# Altium schematic sheet p09_Gig-ENET1.SchDoc
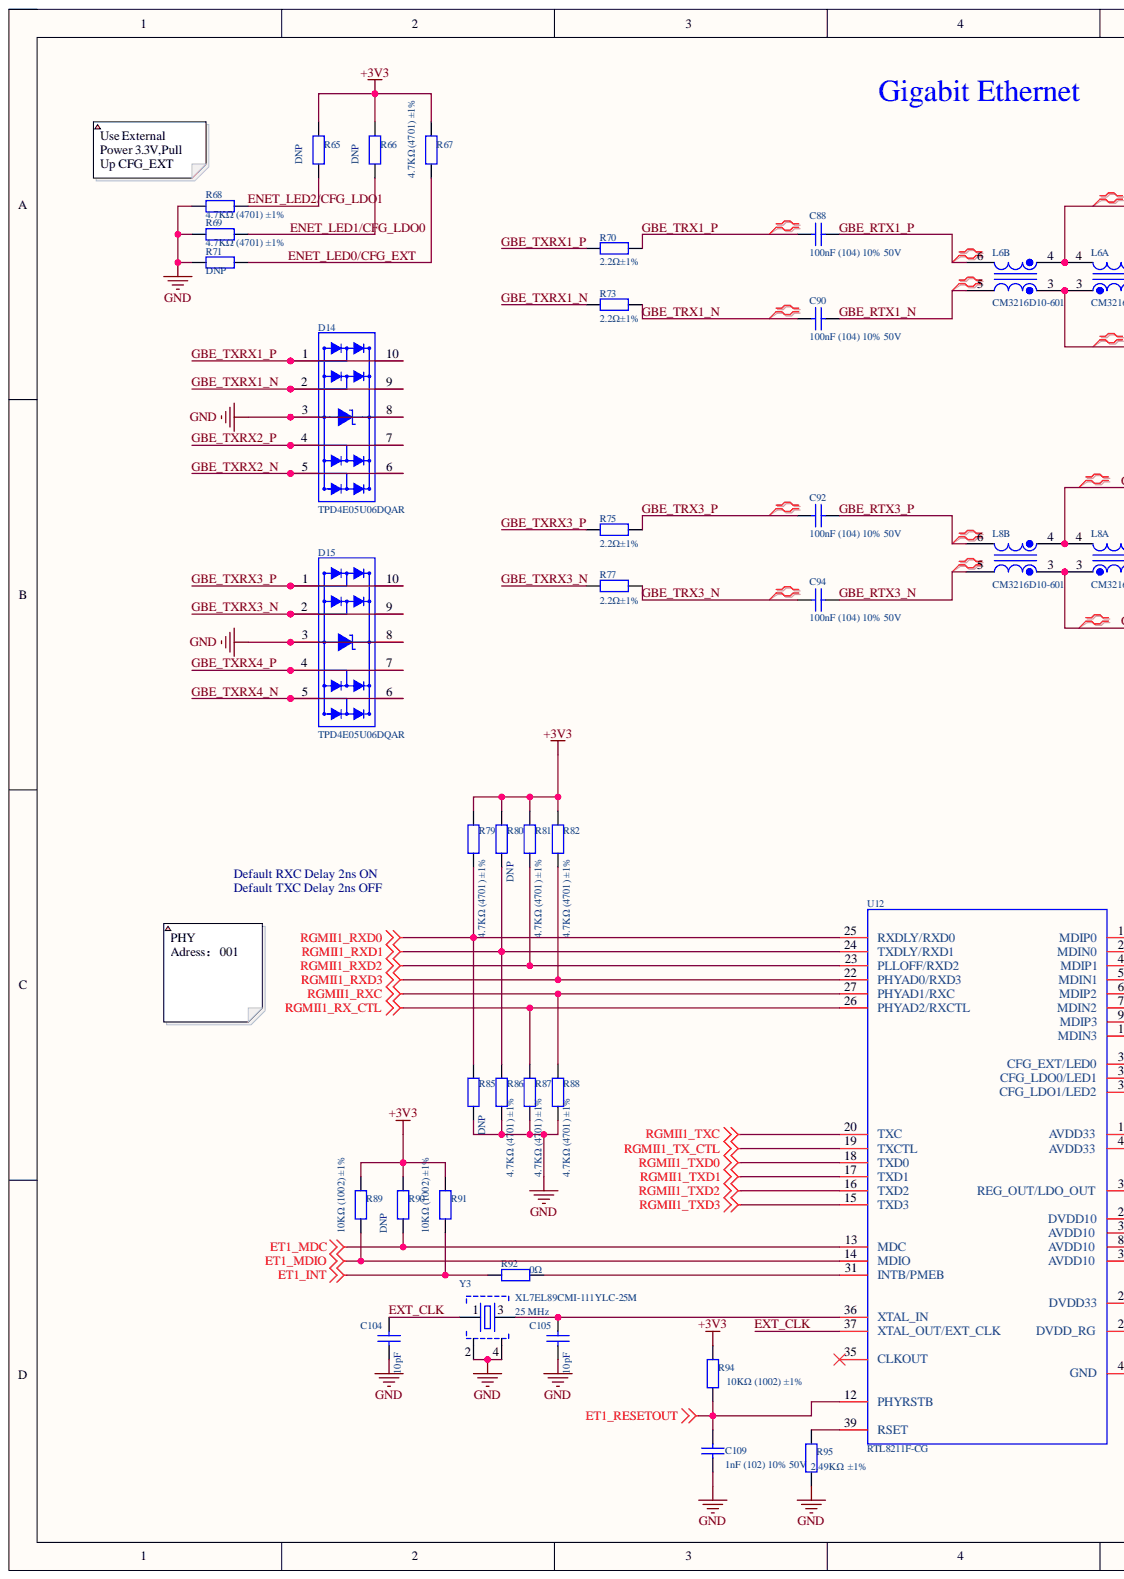
[diagram p0: p09_Gig-ENET1.SchDoc, left]
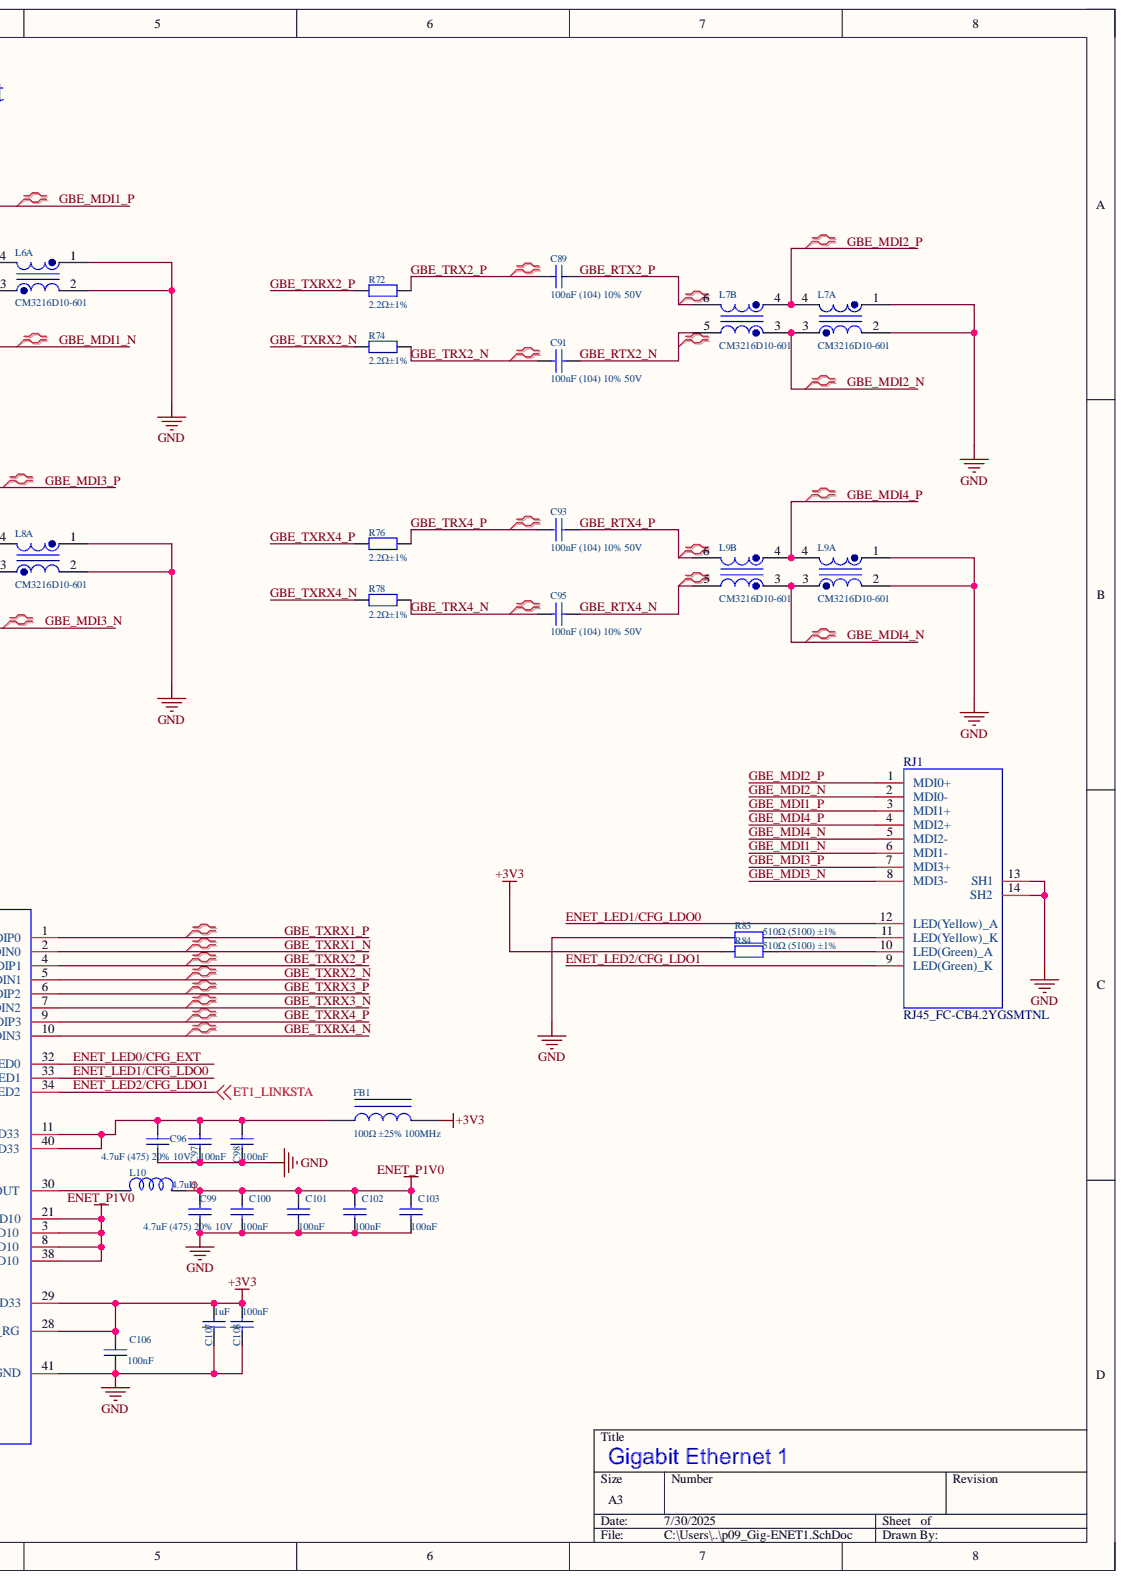
[diagram p1: p09_Gig-ENET1.SchDoc, right]

## Parts
C88  100nF (104) 10% 50V  [C0402]
C89  100nF (104) 10% 50V  [C0402]
C90  100nF (104) 10% 50V  [C0402]
C91  100nF (104) 10% 50V  [C0402]
C92  100nF (104) 10% 50V  [C0402]
C93  100nF (104) 10% 50V  [C0402]
C94  100nF (104) 10% 50V  [C0402]
C95  100nF (104) 10% 50V  [C0402]
C96  4.7uF (475) 20% 10V  [C0402]
C97  Capacitance: 100nF  [C0402]  (hidden comment: 100 nf (0.1uF) 16V)
C98  Capacitance: 100nF  [C0402]  (hidden comment: 100 nf (0.1uF) 16V)
C99  4.7uF (475) 20% 10V  [C0402]
C100  Capacitance: 100nF  [C0402]  (hidden comment: 100 nf (0.1uF) 16V)
C101  Capacitance: 100nF  [C0402]  (hidden comment: 100 nf (0.1uF) 16V)
C102  Capacitance: 100nF  [C0402]  (hidden comment: 100 nf (0.1uF) 16V)
C103  Capacitance: 100nF  [C0402]  (hidden comment: 100 nf (0.1uF) 16V)
C104  Capacitance: 10pF  [C0402]  (hidden comment: 10pF 50V)
C105  Capacitance: 10pF  [C0402]  (hidden comment: 10pF 50V)
C106  Capacitance: 100nF  [C0402]  (hidden comment: 100 nf (0.1uF) 16V)
C107  Capacitance: 1uF  [C0402]  (hidden comment: 1uF 16V)
C108  Capacitance: 100nF  [C0402]  (hidden comment: 100 nf (0.1uF) 16V)
C109  1nF (102) 10% 50V  [C0402]
D14  TPD4E05U06DQAR  [USON-10_L2.5-W1.0-P0.50-BL]
D15  TPD4E05U06DQAR  [USON-10_L2.5-W1.0-P0.50-BL]
FB1  100Ω ±25% 100MHz  [0805_L]
L6  CM3216D10-601  [FILTER-SMD_6P-L3.4-W1.6_SFI1206-601R201]  (parts A,B)
L7  CM3216D10-601  [FILTER-SMD_6P-L3.4-W1.6_SFI1206-601R201]  (parts A,B)
L8  CM3216D10-601  [FILTER-SMD_6P-L3.4-W1.6_SFI1206-601R201]  (parts A,B)
L9  CM3216D10-601  [FILTER-SMD_6P-L3.4-W1.6_SFI1206-601R201]  (parts A,B)
L10  Inductance: 4.7uH  [FB_0805]  (hidden comment: IND-100023-01)
R65  DNP  [R0402]
R66  DNP  [R0402]
R67  4.7KΩ (4701) ±1%  [R0402]
R68  4.7KΩ (4701) ±1%  [R0402]
R69  4.7KΩ (4701) ±1%  [R0402]
R70  2.2Ω±1%  [R0201]
R71  DNP  [R0402]
R72  2.2Ω±1%  [R0201]
R73  2.2Ω±1%  [R0201]
R74  2.2Ω±1%  [R0201]
R75  2.2Ω±1%  [R0201]
R76  2.2Ω±1%  [R0201]
R77  2.2Ω±1%  [R0201]
R78  2.2Ω±1%  [R0201]
R79  4.7KΩ (4701) ±1%  [R0402]
R80  DNP  [R0402]
R81  4.7KΩ (4701) ±1%  [R0402]
R82  4.7KΩ (4701) ±1%  [R0402]
R83  510Ω (5100) ±1%  [R0402]
R84  510Ω (5100) ±1%  [R0402]
R85  DNP  [R0402]
R86  4.7KΩ (4701) ±1%  [R0402]
R87  4.7KΩ (4701) ±1%  [R0402]
R88  4.7KΩ (4701) ±1%  [R0402]
R89  10KΩ (1002) ±1%  [R0402]
R90  DNP  [R0402]
R91  10KΩ (1002) ±1%  [R0402]
R92  0Ω  [R0402]
R94  10KΩ (1002) ±1%  [R0402]
R95  2.49KΩ  ±1%  [R0402]
RJ1  RJ45_FC-CB4.2YGSMTNL  [RJ45-TH_FC-CB4.2YGSMTNL]
U12  RTL8211F-CG  [WQFN-40_L5.0-W5.0-P0.4-BL-EP]
Y3  XL7EL89CMI-111YLC-25M  [CYR-2016]

## Nets
+3V3: C106.1 C107.1 C108.1 FB1.2 R65.2 R66.2 R67.2 R79.2 R80.2 R81.2 R82.2 R84.1 R89.2 R90.2 R91.2 R94.2 U12.28(DVDD_RG) U12.29(DVDD33)
ENET_P1V0: C99.2 C100.2 C101.2 C102.2 C103.2 L10.2 U12.3(AVDD10) U12.8(AVDD10) U12.21(DVDD10) U12.38(AVDD10)
ET1_INT: R91.1 R92.1
ET1_MDC: R90.1 U12.13(MDC)
ET1_MDIO: R89.1 U12.14(MDIO)
ET1_RESETOUT: C109.2 R94.1 U12.12(PHYRSTB)
GND: C96.2 C97.1 C98.2 C99.1 C100.1 C101.1 C102.1 C103.1 C104.1 C105.1 C106.2 C107.2 C108.2 C109.1 D14.3 D14.8 D15.3 D15.8 L6.1 L6.2 L7.1 L7.2 L8.1 L8.2 L9.1 L9.2 R68.1 R69.1 R71.1 R83.1 R85.1 R86.1 R87.1 R88.1 R95.1 RJ1.13(SH1) RJ1.14(SH2) U12.41(GND) Y3.2 Y3.4
RGMII1_RX_CTL: R87.2 U12.26(PHYAD2/RXCTL)
RGMII1_RXC: R88.2 U12.27(PHYAD1/RXC)
RGMII1_RXD0: R79.1 R85.2 U12.25(RXDLY/RXD0)
RGMII1_RXD1: R80.1 R86.2 U12.24(TXDLY/RXD1)
RGMII1_RXD2: R81.1 U12.23(PLLOFF/RXD2)
RGMII1_RXD3: R82.1 U12.22(PHYAD0/RXD3)
RGMII1_TX_CTL: U12.19(TXCTL)
RGMII1_TXC: U12.20(TXC)
RGMII1_TXD0: U12.18(TXD0)
RGMII1_TXD1: U12.17(TXD1)
RGMII1_TXD2: U12.16(TXD2)
RGMII1_TXD3: U12.15(TXD3)
ENET_LED0/CFG_EXT: R67.1 R71.2 U12.32(CFG_EXT/LED0)
ENET_LED1/CFG_LDO0: R66.1 R69.2 RJ1.12(LED(Yellow)_A) U12.33(CFG_LDO0/LED1)
ENET_LED2/CFG_LDO1: R65.1 R68.2 RJ1.9(LED(Green)_K) U12.34(CFG_LDO1/LED2)
EXT_CLK: C104.2 U12.37(XTAL_OUT/EXT_CLK) Y3.1
GBE_MDI1_N: L6.3 RJ1.6(MDI1-)
GBE_MDI1_P: L6.4 RJ1.3(MDI1+)
GBE_MDI2_N: L7.3 RJ1.2(MDI0-)
GBE_MDI2_P: L7.4 RJ1.1(MDI0+)
GBE_MDI3_N: L8.3 RJ1.8(MDI3-)
GBE_MDI3_P: L8.4 RJ1.7(MDI3+)
GBE_MDI4_N: L9.3 RJ1.5(MDI2-)
GBE_MDI4_P: L9.4 RJ1.4(MDI2+)
GBE_RTX1_N: C90.2 L6.5
GBE_RTX1_P: C88.2 L6.6
GBE_RTX2_N: C91.2 L7.5
GBE_RTX2_P: C89.2 L7.6
GBE_RTX3_N: C94.2 L8.5
GBE_RTX3_P: C92.2 L8.6
GBE_RTX4_N: C95.2 L9.5
GBE_RTX4_P: C93.2 L9.6
GBE_TRX1_N: C90.1 R73.2
GBE_TRX1_P: C88.1 R70.2
GBE_TRX2_N: C91.1 R74.2
GBE_TRX2_P: C89.1 R72.2
GBE_TRX3_N: C94.1 R77.2
GBE_TRX3_P: C92.1 R75.2
GBE_TRX4_N: C95.1 R78.2
GBE_TRX4_P: C93.1 R76.2
GBE_TXRX1_N: D14.2 D14.9 R73.1 U12.2(MDIN0)
GBE_TXRX1_P: D14.1 D14.10 R70.1 U12.1(MDIP0)
GBE_TXRX2_N: D14.5 D14.6 R74.1 U12.5(MDIN1)
GBE_TXRX2_P: D14.4 D14.7 R72.1 U12.4(MDIP1)
GBE_TXRX3_N: D15.2 D15.9 R77.1 U12.7(MDIN2)
GBE_TXRX3_P: D15.1 D15.10 R75.1 U12.6(MDIP2)
GBE_TXRX4_N: D15.5 D15.6 R78.1 U12.10(MDIN3)
GBE_TXRX4_P: D15.4 D15.7 R76.1 U12.9(MDIP3)
NetC96_1: C96.1 C97.2 C98.1 FB1.1 U12.11(AVDD33) U12.40(AVDD33)
NetC105_2: C105.2 U12.36(XTAL_IN) Y3.3
NetL10_1: L10.1 U12.30(REG_OUT/LDO_OUT)
NetR83_2: R83.2 RJ1.11(LED(Yellow)_K)
NetR84_2: R84.2 RJ1.10(LED(Green)_A)
NetR92_2: R92.2 U12.31(INTB/PMEB)
NetR95_2: R95.2 U12.39(RSET)

Not connected: U12 35(CLKOUT)

## Library data of the parts
C88-C95 = 0402 100nF (104) 10% 50V: 贴片电容; Component Kind: Standard; ComponentLink1Description: 供应商链接; ComponentLink1URL: https://item.szlcsc.com/111473.html; Library Name: Cap.SchLib; Package: 0402; Supplier: LC; SuppliersPartNumber: C1525
C96 C99 = 0402 4.7uF (475) 20% 10V: 贴片电容; Component Kind: Standard; ComponentLink1Description: 供应商链接; ComponentLink1URL: http://www.szlcsc.com/product/details_24469.html; Library Name: Cap.SchLib; Package: 0402; Supplier: LC; SuppliersPartNumber: C23733
C97 C98 C100-C103 C106 C108 = CAP-000030-01: Ceramic Chip Capacitor 0402 100 nf (0.1uF) 16V; Legacy Part Number: 151-10004022; Manufacturer: Yageo; Manufacturer Part Number 1: CC0402KRX7R8BB104; Dialectric: X7R; Notes: ANY EQUIVALENT; Tolerance: 10%; Component Kind: Standard; Library Name: ra8p1_v0.1.SCHLIB; Manufacturer 1: Yageo; Manufacturer 2: Kemet; Manufacturer 3: AVX; Manufacturer Part Number 2: C0402C104K4RACTU; Manufacturer Part Number 3: 0402YC104KAT2A; Mfg: Yageo; Mfg P/N: CC0402KRX7R8BB104; Mfg Part Number: CC0402KRX7R8BB104; Package: 0402; PN: CC0402KRX7R8BB104; Supplier 1: Arrow Electronics; Supplier 2: Digi-Key; Supplier 3: Newark; Supplier 4: Mouser; Supplier Part Number 1: CC0402KRX7R8BB104; Supplier Part Number 2: 311-2077-1-ND; Supplier Part Number 3: 40AJ6370; Supplier Part Number 4: 603-CC402KRX7R8BB104; Voltage: 16V
C104 C105 = CAP-100118-01: Ceramic Chip Capacitor 0402 10pF 50V; Legacy Part Number: 151-10005153; Manufacturer: Yageo; Manufacturer Part Number 1: CC0402JRNPO9BN100; Dialectric: COG/NPO; Notes: ANY EQUIVALENT; Tolerance: 5%; Component Kind: Standard; Library Name: Capacitors.IntLib; Manufacturer 1: Yageo; Mfg: Yageo; Mfg P/N: CC0402JRNPO9BN100; Mfg Part Number: CC0402JRNPO9BN100; Package: 0402; PN: CC0402JRNPO9BN100; Supplier 1: Digi-Key; Supplier Part Number 1: 311-1014-1-ND; Voltage: 50V
C107 = CAP-000063-01: Ceramic Chip Capacitor 0402 1uF 16V; Manufacturer: Murata; Manufacturer Part Number 1: GRM155R61E105MA12D; Dialectric: X5R; Notes: ANY EQUIVALENT; Tolerance: 10%; Value: 1u; Component Kind: Standard; Library Name: ra8p1_v0.1.SCHLIB; Manufacturer 1: Murata; Manufacturer 2: Samsung; Manufacturer Part Number 2: CL05A105KO5NNNC; Mfg: Murata; Mfg P/N: GRM155R61E105MA12D; Mfg Part Number: GRM155R61E105MA12D; Package: 0402; PN: GRM155R61E105MA12D; Simulation: C0402_X5R_1UF; Supplier 1: Digi-Key; Supplier 2: Digi-Key; Supplier 3: Digi-Key; Supplier Part Number 1: 1276-1067-1-ND; Supplier Part Number 2: 1276-1067-6-ND; Supplier Part Number 3: 490-10018-1-ND; Voltage: 16V
C109 = 0402 1nF (102) 10% 50V: 贴片电容; Component Kind: Standard; ComponentLink1Description: 供应商链接; ComponentLink1URL: http://www.szlcsc.com/product/details_1875.html; Library Name: Cap.SchLib; Package: 0402; Supplier: LC; SuppliersPartNumber: C1523
D14 D15 = TPD4E05U06DQAR: Manufacture: SOCAY(硕凯); Part Name: ESD; Part Number: C040504013981; DSC1: 双向; DSC2: Vdc=5V; DSC3: Vbr=8V; DSC4: Vc=20V; DSC5: Cj=0.4pF; ERP Number: 1010060056; ID: 8; PCB Footprint: DFN2510-10L; Solder: 推荐; Component Kind: Standard; Date: 05132005; Library Name: ra8p1_v0.1.SCHLIB; Price: 0.15
FB1 = 0805 100Ω 25% 100MHz: 贴片磁珠; Component Kind: Standard; ComponentLink1Description: 供应商链接; ComponentLink1URL: http://www.szlcsc.com/product/details_1367.html; Library Name: IUD.SchLib; Package: 0805; Supplier: LC; SuppliersPartNumber: C1015
L6-L9 = CM3216D10-601: Cap+CMC Solution, Inductance=55uH(100Khz), 0.2A,125V; Component Kind: Standard; Library Name: ra8p1_v0.2.SCHLIB
L10 = IND-100023-01: Chip Inductor, 4.7uH Shielded Multilayer, 700mA 260mohm 0805 20%; Manufacturer: TDK; DisplayName: MLP2012S4R7MT0S1; Component Kind: Standard; Current: 700mA; DC Resistance: 260mohm; Library Name: Misc.IntLib; Manufacturer 1: TDK; Manufacturer Part Number 1: TDK; Mfg: TDK; Mfg Part Number: TDK; Package: 0805; PN: MLP2012S4R7MT0S1; Supplier 1: Arrow Electronics; Supplier 2: Digi-Key; Supplier 3: Mouser; Supplier 4: Digi-Key; Supplier 5: Mouser; Supplier Part Number 1: MLP2012S4R7MT0S1; Supplier Part Number 2: 445-6366-1-ND; Supplier Part Number 3: 810-MLP2012S4R7MT0S1; Supplier Part Number 4: 553-BKPB002012104R7MA2CT-ND; Supplier Part Number 5: 603-BKPB2012104R7MA2; Tolerance: 20%
R65 R66 R71 R80 R85 = 0402 4.7KΩ (4701) 1%: 贴片电阻,4.7K; Component Kind: Standard; ComponentLink1Description: 供应商链接; ComponentLink1URL: http://www.szlcsc.com/product/details_26643.html; Library Name: RES.SchLib; Package: 0402; Supplier: LC; SuppliersPartNumber: C25900
R67-R69 R79 R81 R82 R86-R88 = 0402 4.7KΩ (4701) 1%: 贴片电阻; Component Kind: Standard; ComponentLink1Description: 供应商链接; ComponentLink1URL: http://www.szlcsc.com/product/details_26643.html; Library Name: RES.SchLib; Package: 0402; Supplier: LC; SuppliersPartNumber: C25900
R70 R72-R78 = 0402 2.2Ω 1%: 贴片电阻; Component Kind: Standard; ComponentLink1Description: 供应商链接; ComponentLink1URL: https://item.szlcsc.com/25844.html?fromZone=s_s__%25222.2r%2522&spm=sc.gb.xh1.zy.n___sc.gb.hd.ss&lcsc_vid=E1YIU1FRQVIMBVNRRVhcBAYDQwJbUQICQFgLBVMDElIxVlNSQlJZX1dWRVBcUjsOAxUeFF5JWBYZEEoVDQ0NFAdIFA4DSA%3D%3D; Library Name: RES.SchLib; Package: 0402; Supplier: LC; SuppliersPartNumber: C25879
R83 R84 = 0402 510Ω (5100) 1%: 贴片电阻; Component Kind: Standard; ComponentLink1Description: 供应商链接; ComponentLink1URL: http://www.szlcsc.com/product/details_25866.html; Library Name: RES.SchLib; Package: 0402; Supplier: LC; SuppliersPartNumber: C25123
R89-R91 R94 = 0402 10KΩ (1002) 1%: 贴片电阻，10K; Component Kind: Standard; ComponentLink1Description: 供应商链接; ComponentLink1URL: http://www.szlcsc.com/product/details_26487.html; Library Name: RES.SchLib; Package: 0402; Supplier: LC; SuppliersPartNumber: C25744
R92 = 0402 0Ω (0R0) 1%: 贴片电阻; Component Kind: Standard; ComponentLink1Description: 供应商链接; ComponentLink1URL: http://www.szlcsc.com/product/details_17853.html; Library Name: RES.SchLib; Package: 0402; Supplier: LC; SuppliersPartNumber: C17168
R95 = 0402 2.49KΩ 1%: 贴片电阻; Component Kind: Standard; ComponentLink1Description: 供应商链接; ComponentLink1URL: http://www.szlcsc.com/product/details_26625.html; Library Name: ra8p1_v0.1.SCHLIB; Package: 0402; Supplier: LC; SuppliersPartNumber: C25882
RJ1 = RJ45_FC-CB4.2YGSMTNL: Connector_RJ45, single port, with LEDS,SMD; Internal_Part_Number: MCD-00717; Manufacturer: DONGGUAN KEYOUDA ELECTRONIC TECHNOLOGY; Part_Preference: Recommended; Component_Country_Of_Origin: Unknown; ID: 3029; ShowFields: YES; Component Kind: Standard; ComponentLink1URL: http://tunmcdsvn.tun.st.com/Component_Documentation_Library/Trunk/Connector/DONGGUAN_KEYOUDA_ELECTRONIC_TECHNOLOGY_KRJ-CB4.2GYZNL/; Library Name: ra8p1_v0.2.SCHLIB; Manufacturer_Part_Number: RJ45_FC-CB4.2YGSMTNL; Order_Code_Digikey: N/A; Order_Code_Farnell: N/A; Order_Code_RS: N/A; Part_Type: Connector; Status: Released; Value: RJ45_FC-CB4.2YGSMTNL
U12 = RTL8211F-CG: RGMII; Manufacturer: REALTEK(瑞昱); Add into BOM: yes; Number of Channels: 4; Supply Voltage: 1.8V；2.5V；3.3V；1.5V; Application Function: -; Component Kind: Standard; Convert to PCB: yes; Datasheet: https://atta.szlcsc.com/upload/public/pdf/source/20190902/C187932_1913F3711F05FE1324DF2946D70D648D.pdf; Device: RTL8211F-CG; Interface: RGMII; JLCPCB Part Class: Extended Part; LCSC Part Name: 集成10/100/1000M以太网精密收发器; Library Name: ra8p1_v0.1.SCHLIB; Manufacturer Part: RTL8211F-CG; PCB3D: WQFN-40_L5.0-W5.0-P0.4-BL-EP; Supplier: LCSC; Supplier Footprint: WQFN-40-EP(5x5); Supplier Part: C187932; Symbol: RTL8211F-CG
Y3 = XTL-100008-01: 25MHz ±10ppm 10pF，100ohom ESR  XL7EL89CMI-111YLC-25M; Manufacturer: ECS; Manufacturer Part Number 1: ECS-250-10-37B-CTN-TR; ESR: 100 ohm; Freq Stability: +/- 20ppm; Freq Tolerance: +/- 10ppm; Load Capacitance: 10pF; Notes: MUST USE SPECIFIC; Component Kind: Standard; ComponentLink1Description: New link; ComponentLink1URL: https://item.szlcsc.com/23919117.html?fromZone=l_b__%2522brand%2522&spm=sc.bidn.xh6.zy.n___sc.gbn.xh1.zy.p&lcsc_vid=FlRcAQUARlUMU1NWEQALBQBeQllfVQcHQVAMX1FeFAcxVlNSTlBXXlFTQFBfUDsOAxUeFF5JWAgaAglIBBsCBBcFWQIBCks%3D; Frequency: 25 MHz; Library Name: Misc.IntLib; Manufacturer 1: ECS; Mfg: ECS; Mfg Part Number: ECS-250-10-37B-CTN-TR; PN: ECS-250-10-37B-CTN-TR; Supplier 1: Avnet; Supplier 2: Mouser; Supplier 3: Digi-Key; Supplier Part Number 1: ECS-250-10-37B-CTN-TR; Supplier Part Number 2: 520-250-10-37B-CTNT; Supplier Part Number 3: XC3065CT-ND
